# Altium schematic sheet main.SchDoc(main)
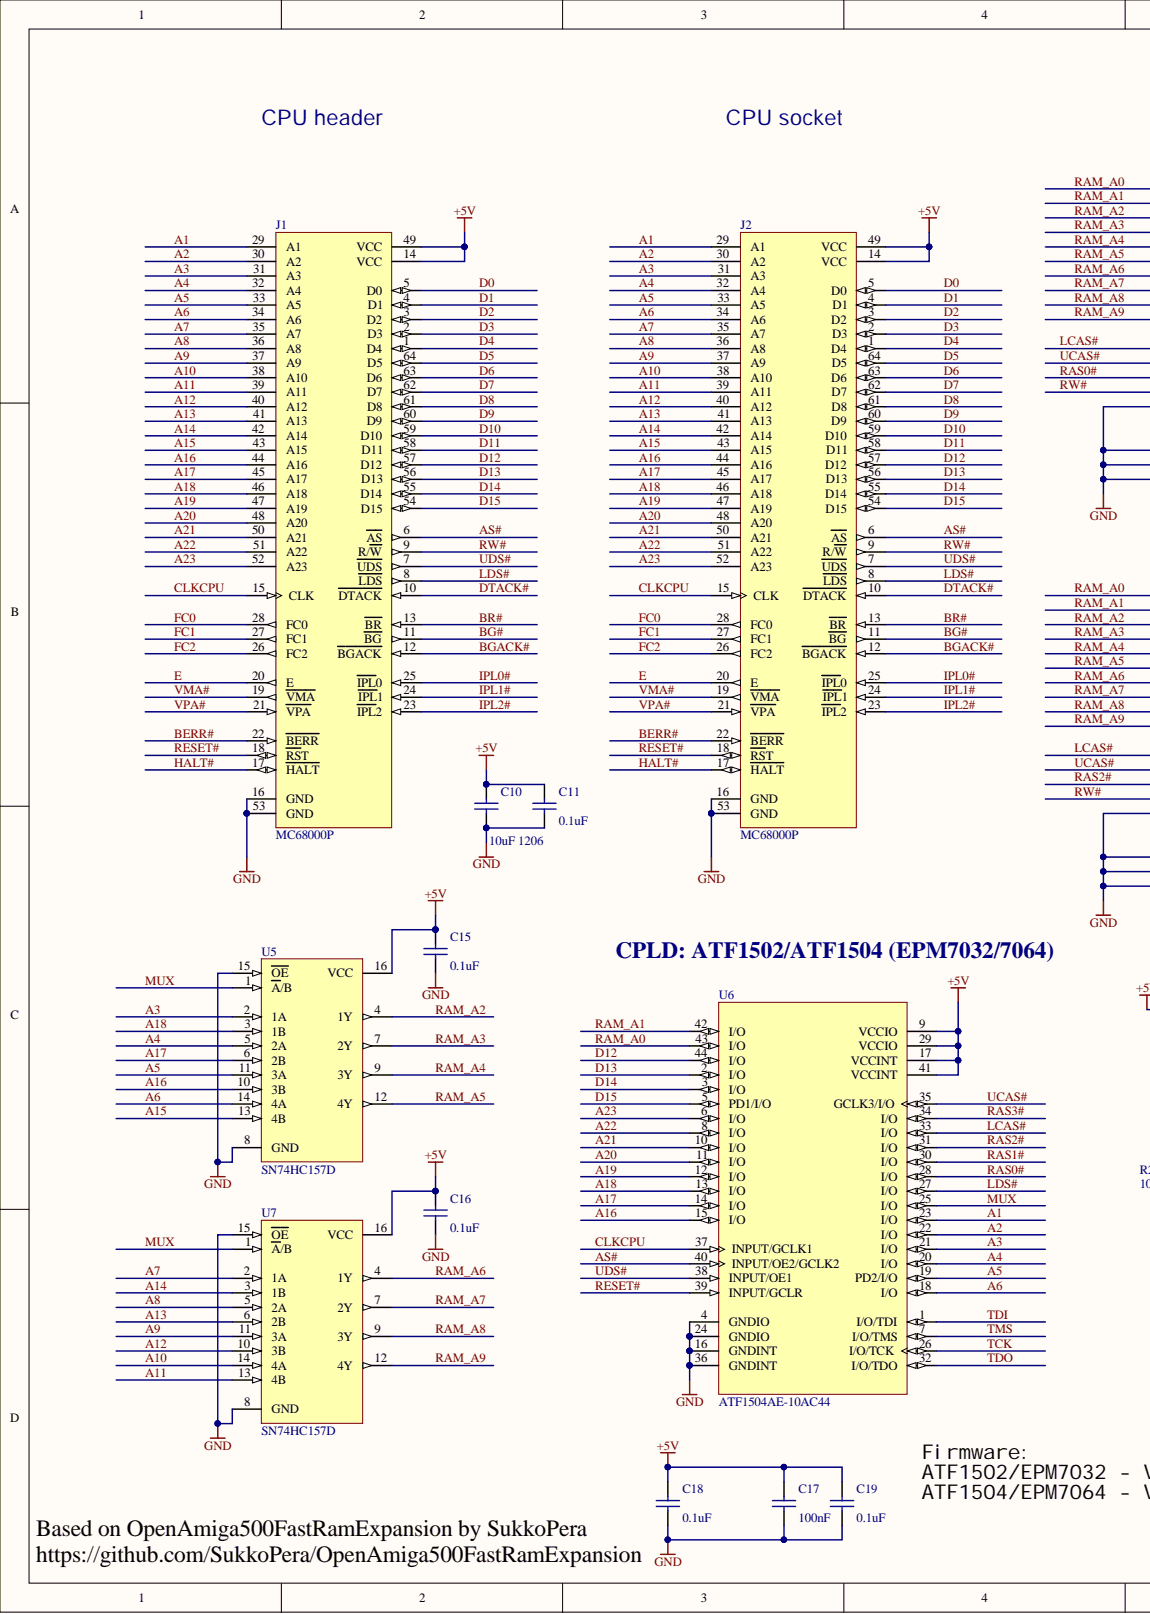
[diagram p0: main.SchDoc, left]
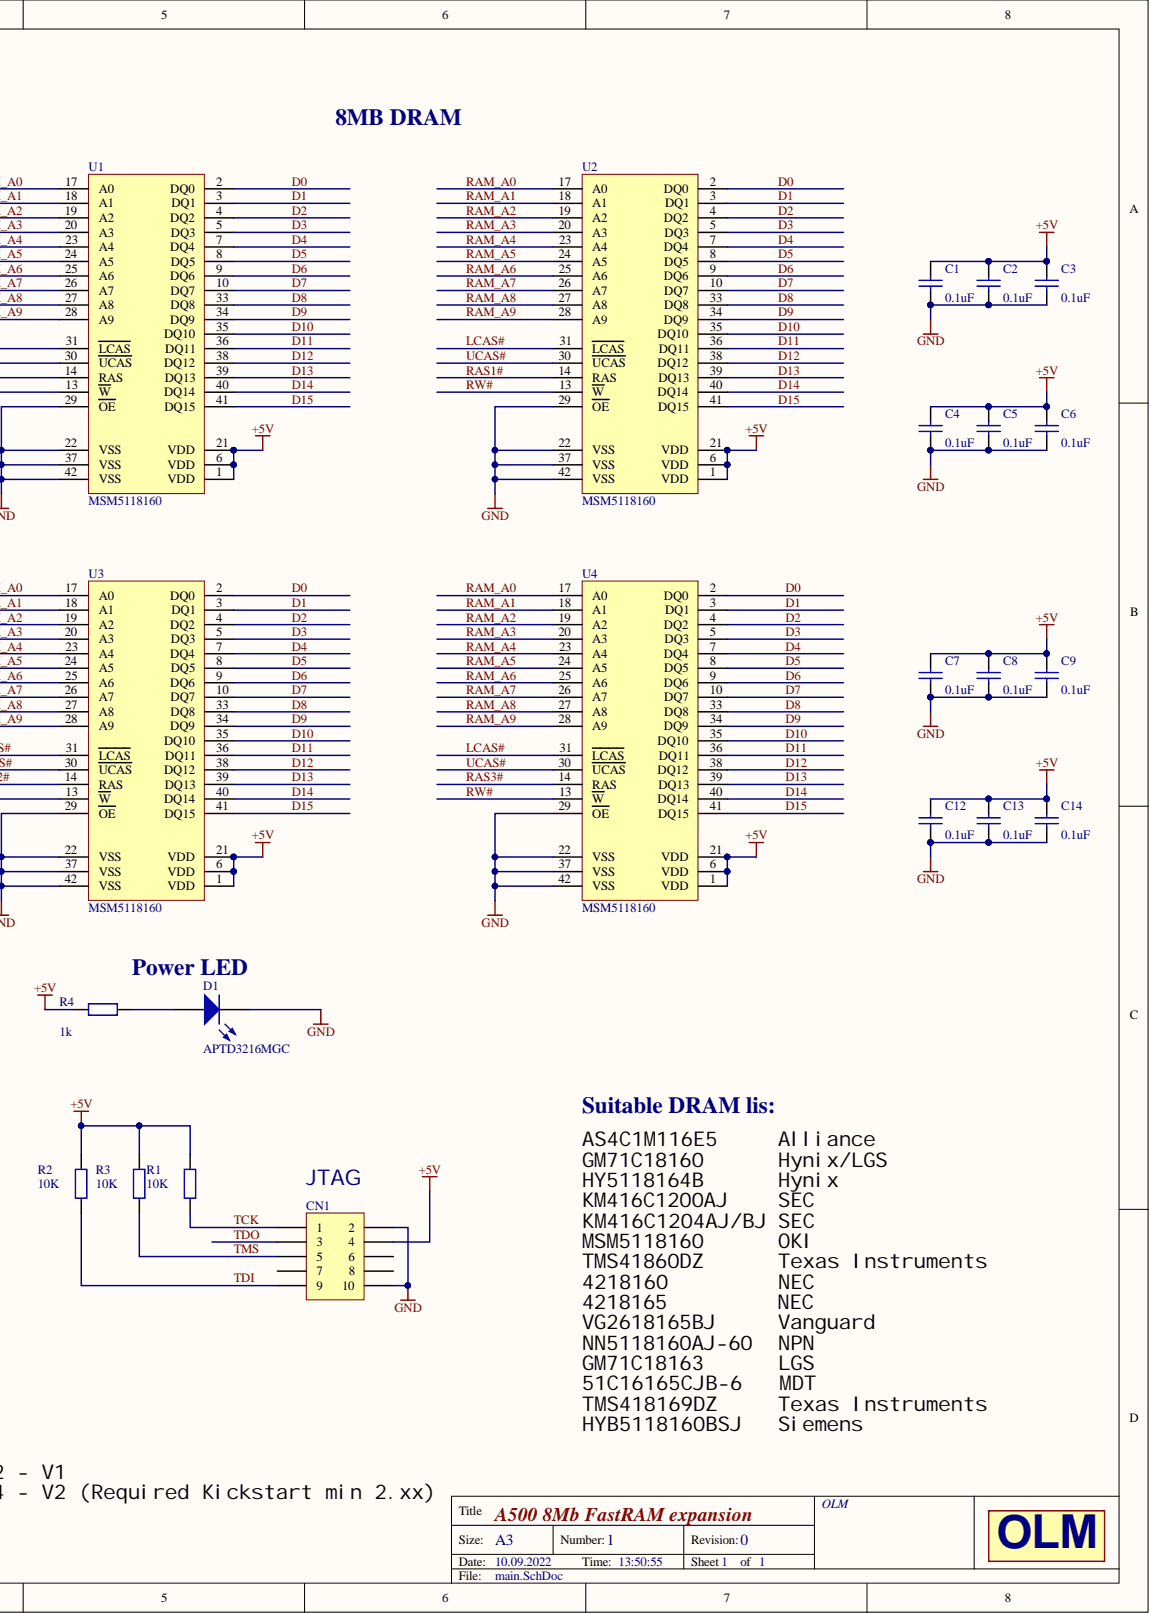
[diagram p1: main.SchDoc, right]

## Parts
C1  value 0.1uF  [SM/C_0805]  (hidden comment: Capacitor)
C2  value 0.1uF  [SM/C_0805]  (hidden comment: Capacitor)
C3  value 0.1uF  [SM/C_0805]  (hidden comment: Capacitor)
C4  value 0.1uF  [SM/C_0805]  (hidden comment: Capacitor)
C5  value 0.1uF  [SM/C_0805]  (hidden comment: Capacitor)
C6  value 0.1uF  [SM/C_0805]  (hidden comment: Capacitor)
C7  value 0.1uF  [SM/C_0805]  (hidden comment: Capacitor)
C8  value 0.1uF  [SM/C_0805]  (hidden comment: Capacitor)
C9  value 0.1uF  [SM/C_0805]  (hidden comment: Capacitor)
C10  value 10uF 1206  [SM/C_1206]  (hidden comment: Capacitor)
C11  value 0.1uF  [SM/C_0805]  (hidden comment: Capacitor)
C12  value 0.1uF  [SM/C_0805]  (hidden comment: Capacitor)
C13  value 0.1uF  [SM/C_0805]  (hidden comment: Capacitor)
C14  value 0.1uF  [SM/C_0805]  (hidden comment: Capacitor)
C15  value 0.1uF  [SM/C_0805]  (hidden comment: Capacitor)
C16  value 0.1uF  [SM/C_0805]  (hidden comment: Capacitor)
C17  value 100nF  [SM/C_0805]  (hidden comment: Cap)
C18  value 0.1uF  [SM/C_0805]  (hidden comment: Capacitor)
C19  value 0.1uF  [SM/C_0805]  (hidden comment: Capacitor)
CN1  Header 5X2H  [PLD-10]  (value not drawn)
D1  value APTD3216MGC  [SM/LED_1206_Green]  (hidden comment: Светодиод)
J1  MC68000P  [DIP.100/64/W.900/L3.225-HEADER]
J2  MC68000P  [DIP.100/64/W.900/L3.225-SKT]
R1  value 10K  [SM/R_0805]  (hidden comment: Resistor)
R2  value 10K  [SM/R_0805]  (hidden comment: Resistor)
R3  value 10K  [SM/R_0805]  (hidden comment: Resistor)
R4  value 1k  [SM/R_0805]  (hidden comment: Resistor)
U1  value MSM5118160  [SOJ-42/400mil]  (hidden comment: 1Mx16 DRAM SOJ42)
U2  value MSM5118160  [SOJ-42/400mil]  (hidden comment: 1Mx16 DRAM SOJ42)
U3  value MSM5118160  [SOJ-42/400mil]  (hidden comment: 1Mx16 DRAM SOJ42)
U4  value MSM5118160  [SOJ-42/400mil]  (hidden comment: 1Mx16 DRAM SOJ42)
U5  SN74HC157D  [D016_N]
U6  ATF1504AE-10AC44  [44A_N]
U7  SN74HC157D  [D016_N]

## Nets
+5V: C1.2 C2.2 C3.2 C4.2 C5.2 C6.2 C7.2 C8.2 C9.2 C10.2 C11.2 C12.2 C13.2 C14.2 C15.2 C16.2 C17.2 C18.2 C19.2 CN1.4 J1.14(VCC) J1.49(VCC) J2.14(VCC) J2.49(VCC) R1.2 R2.2 R3.2 R4.2 U1.1(VDD) U1.6(VDD) U1.21(VDD) U2.1(VDD) U2.6(VDD) U2.21(VDD) U3.1(VDD) U3.6(VDD) U3.21(VDD) U4.1(VDD) U4.6(VDD) U4.21(VDD) U5.16(VCC) U6.9(VCCIO) U6.17(VCCINT) U6.29(VCCIO) U6.41(VCCINT) U7.16(VCC)
GND: C1.1 C2.1 C3.1 C4.1 C5.1 C6.1 C7.1 C8.1 C9.1 C10.1 C11.1 C12.1 C13.1 C14.1 C15.1 C16.1 C17.1 C18.1 C19.1 CN1.2 CN1.10 D1.2 J1.16(GND) J1.53(GND) J2.16(GND) J2.53(GND) U1.22(VSS) U1.29(OE) U1.37(VSS) U1.42(VSS) U2.22(VSS) U2.29(OE) U2.37(VSS) U2.42(VSS) U3.22(VSS) U3.29(OE) U3.37(VSS) U3.42(VSS) U4.22(VSS) U4.29(OE) U4.37(VSS) U4.42(VSS) U5.8(GND) U5.15(OE) U6.4(GNDIO) U6.16(GNDINT) U6.24(GNDIO) U6.36(GNDINT) U7.8(GND) U7.15(OE)
A1: J1.29(A1) J2.29(A1) U6.23(I/O)
A2: J1.30(A2) J2.30(A2) U6.22(I/O)
A3: J1.31(A3) J2.31(A3) U5.2(1A) U6.21(I/O)
A4: J1.32(A4) J2.32(A4) U5.5(2A) U6.20(I/O)
A5: J1.33(A5) J2.33(A5) U5.11(3A) U6.19(PD2/I/O)
A6: J1.34(A6) J2.34(A6) U5.14(4A) U6.18(I/O)
A7: J1.35(A7) J2.35(A7) U7.2(1A)
A8: J1.36(A8) J2.36(A8) U7.5(2A)
A9: J1.37(A9) J2.37(A9) U7.11(3A)
A10: J1.38(A10) J2.38(A10) U7.14(4A)
A11: J1.39(A11) J2.39(A11) U7.13(4B)
A12: J1.40(A12) J2.40(A12) U7.10(3B)
A13: J1.41(A13) J2.41(A13) U7.6(2B)
A14: J1.42(A14) J2.42(A14) U7.3(1B)
A15: J1.43(A15) J2.43(A15) U5.13(4B)
A16: J1.44(A16) J2.44(A16) U5.10(3B) U6.15(I/O)
A17: J1.45(A17) J2.45(A17) U5.6(2B) U6.14(I/O)
A18: J1.46(A18) J2.46(A18) U5.3(1B) U6.13(I/O)
A19: J1.47(A19) J2.47(A19) U6.12(I/O)
A20: J1.48(A20) J2.48(A20) U6.11(I/O)
A21: J1.50(A21) J2.50(A21) U6.10(I/O)
A22: J1.51(A22) J2.51(A22) U6.8(I/O)
A23: J1.52(A23) J2.52(A23) U6.6(I/O)
AS#: J1.6(AS) J2.6(AS) U6.40(INPUT/OE2/GCLK2)
BERR#: J1.22(BERR) J2.22(BERR)
BG#: J1.11(BG) J2.11(BG)
BGACK#: J1.12(BGACK) J2.12(BGACK)
BR#: J1.13(BR) J2.13(BR)
CLKCPU: J1.15(CLK) J2.15(CLK) U6.37(INPUT/GCLK1)
D0: J1.5(D0) J2.5(D0) U1.2(DQ0) U2.2(DQ0) U3.2(DQ0) U4.2(DQ0)
D1: J1.4(D1) J2.4(D1) U1.3(DQ1) U2.3(DQ1) U3.3(DQ1) U4.3(DQ1)
D2: J1.3(D2) J2.3(D2) U1.4(DQ2) U2.4(DQ2) U3.4(DQ2) U4.4(DQ2)
D3: J1.2(D3) J2.2(D3) U1.5(DQ3) U2.5(DQ3) U3.5(DQ3) U4.5(DQ3)
D4: J1.1(D4) J2.1(D4) U1.7(DQ4) U2.7(DQ4) U3.7(DQ4) U4.7(DQ4)
D5: J1.64(D5) J2.64(D5) U1.8(DQ5) U2.8(DQ5) U3.8(DQ5) U4.8(DQ5)
D6: J1.63(D6) J2.63(D6) U1.9(DQ6) U2.9(DQ6) U3.9(DQ6) U4.9(DQ6)
D7: J1.62(D7) J2.62(D7) U1.10(DQ7) U2.10(DQ7) U3.10(DQ7) U4.10(DQ7)
D8: J1.61(D8) J2.61(D8) U1.33(DQ8) U2.33(DQ8) U3.33(DQ8) U4.33(DQ8)
D9: J1.60(D9) J2.60(D9) U1.34(DQ9) U2.34(DQ9) U3.34(DQ9) U4.34(DQ9)
D10: J1.59(D10) J2.59(D10) U1.35(DQ10) U2.35(DQ10) U3.35(DQ10) U4.35(DQ10)
D11: J1.58(D11) J2.58(D11) U1.36(DQ11) U2.36(DQ11) U3.36(DQ11) U4.36(DQ11)
D12: J1.57(D12) J2.57(D12) U1.38(DQ12) U2.38(DQ12) U3.38(DQ12) U4.38(DQ12) U6.44(I/O)
D13: J1.56(D13) J2.56(D13) U1.39(DQ13) U2.39(DQ13) U3.39(DQ13) U4.39(DQ13) U6.2(I/O)
D14: J1.55(D14) J2.55(D14) U1.40(DQ14) U2.40(DQ14) U3.40(DQ14) U4.40(DQ14) U6.3(I/O)
D15: J1.54(D15) J2.54(D15) U1.41(DQ15) U2.41(DQ15) U3.41(DQ15) U4.41(DQ15) U6.5(PD1/I/O)
DTACK#: J1.10(DTACK) J2.10(DTACK)
E: J1.20(E) J2.20(E)
FC0: J1.28(FC0) J2.28(FC0)
FC1: J1.27(FC1) J2.27(FC1)
FC2: J1.26(FC2) J2.26(FC2)
HALT#: J1.17(HALT) J2.17(HALT)
IPL0#: J1.25(IPL0) J2.25(IPL0)
IPL1#: J1.24(IPL1) J2.24(IPL1)
IPL2#: J1.23(IPL2) J2.23(IPL2)
LCAS#: U1.31(LCAS) U2.31(LCAS) U3.31(LCAS) U4.31(LCAS) U6.33(I/O)
LDS#: J1.8(LDS) J2.8(LDS) U6.27(I/O)
MUX: U5.1(A/B) U6.25(I/O) U7.1(A/B)
RAM_A0: U1.17(A0) U2.17(A0) U3.17(A0) U4.17(A0) U6.43(I/O)
RAM_A1: U1.18(A1) U2.18(A1) U3.18(A1) U4.18(A1) U6.42(I/O)
RAM_A2: U1.19(A2) U2.19(A2) U3.19(A2) U4.19(A2) U5.4(1Y)
RAM_A3: U1.20(A3) U2.20(A3) U3.20(A3) U4.20(A3) U5.7(2Y)
RAM_A4: U1.23(A4) U2.23(A4) U3.23(A4) U4.23(A4) U5.9(3Y)
RAM_A5: U1.24(A5) U2.24(A5) U3.24(A5) U4.24(A5) U5.12(4Y)
RAM_A6: U1.25(A6) U2.25(A6) U3.25(A6) U4.25(A6) U7.4(1Y)
RAM_A7: U1.26(A7) U2.26(A7) U3.26(A7) U4.26(A7) U7.7(2Y)
RAM_A8: U1.27(A8) U2.27(A8) U3.27(A8) U4.27(A8) U7.9(3Y)
RAM_A9: U1.28(A9) U2.28(A9) U3.28(A9) U4.28(A9) U7.12(4Y)
RAS0#: U1.14(RAS) U6.28(I/O)
RAS1#: U2.14(RAS) U6.30(I/O)
RAS2#: U3.14(RAS) U6.31(I/O)
RAS3#: U4.14(RAS) U6.34(I/O)
RESET#: J1.18(RST) J2.18(RST) U6.39(INPUT/GCLR)
RW#: J1.9(R/W) J2.9(R/W) U1.13(W) U2.13(W) U3.13(W) U4.13(W)
TCK: CN1.1 R1.1 U6.26(I/O/TCK)
TDI: CN1.9 R2.1 U6.1(I/O/TDI)
TDO: CN1.3 U6.32(I/O/TDO)
TMS: CN1.5 R3.1 U6.7(I/O/TMS)
UCAS#: U1.30(UCAS) U2.30(UCAS) U3.30(UCAS) U4.30(UCAS) U6.35(GCLK3/I/O)
UDS#: J1.7(UDS) J2.7(UDS) U6.38(INPUT/OE1)
VMA#: J1.19(VMA) J2.19(VMA)
VPA#: J1.21(VPA) J2.21(VPA)
NetD1_1: D1.1 R4.1

Not connected: CN1 6 7 8

## Library data of the parts
C1-C9 C11-C16 C18 C19 = Cap ceramic: Ceramic capacitor SMD; Manufacturer: Samsung; Part: CL10B104KB8NNNL; Component Kind: Standard; Library Name: New.SCHLIB
C10 = Cap ceramic: Ceramic capacitor SMD; Manufacturer: Kemet; Part: C1206C106K4RACTU; Value: 10uF 1206; Component Kind: Standard; Library Name: New.SCHLIB
C17 = Cap ceramic: CAP-100Nf; Component Kind: Standard; Library Name: New.SCHLIB
CN1 = Header 5X2H: Header, 5-Pin, Dual row, Right Angle; Component Kind: Standard; LatestRevisionDate: 17-Jul-2002; LatestRevisionNote: Re-released for DXP Platform.; Library Name: Miscellaneous Connectors.IntLib; Signal Integrity: Connector; Publisher: Altium Limited
D1 = LED0: Typical INFRARED GaAs LED; Примечание: Kingbright; Component Kind: Standard; LatestRevisionDate: 08-Jul-2005; LatestRevisionNote: Mech Layer 1 removed.; Library Name: Miscellaneous Devices.IntLib; PackageDescription: LED; 2 Leads; PackageReference: LED-0; Published: 8-Jun-2000; Simulation: LED0; Publisher: Altium Limited
J1 J2 = MC68000P: 16-Bit/32-Bit Microprocessor; Value: Socket 1-pin x 64; Component Kind: Standard; DatasheetVersion: 1990; LatestRevisionDate: 06-Jun-2005; LatestRevisionNote: Stylized 3D Model Added.; Library Name: Motorola Microprocessor 16-Bit.IntLib; PackageDescription: DIP; 64 Leads; Row Spacing 22.86 mm; Pitch 2.54 mm; PackageReference: 754-01; PCB3D: 746-01; Published: 17-Jul-2002; Publisher: Altium Limited
R1-R3 = Res2: Part: 0R 5% 0603; Component Kind: Standard; Description: Resistor; LatestRevisionDate: 17-Jul-2002; LatestRevisionNote: Re-released for DXP Platform.; Library Name: Miscellaneous Devices.IntLib; PackageReference: AXIAL-0.4; Published: 8-Jun-2000; Signal Integrity: Res; Simulation: RESISTOR; Publisher: Altium Limited
R4 = Res2: Component Kind: Standard; Description: Resistor; LatestRevisionDate: 17-Jul-2002; LatestRevisionNote: Re-released for DXP Platform.; Library Name: Miscellaneous Devices.IntLib; PackageReference: AXIAL-0.4; Published: 8-Jun-2000; Signal Integrity: Res; Simulation: RESISTOR; Publisher: Altium Limited
U1-U4 = 1Mx16 DRAM: Component Kind: Standard; Description: 1Mx16 DRAM; Library Name: Memory.SchLib
U5 U7 = SN74HC157D: Quadruple 2-Line to 1-Line Data Selector/Multiplexer; Code_IPC: SOIC127P600-16; Component Kind: Standard; ComponentLink1Description: Manufacturer Link; ComponentLink1URL: http://www.ti.com/; ComponentLink2Description: Datasheet; ComponentLink2URL: http://www-s.ti.com/sc/ds/sn74hc157.pdf; DatasheetVersion: May-1997; LatestRevisionDate: 13-Apr-2006; LatestRevisionNote: IPC-7351 Footprint Added.; Library Name: TI Logic Multiplexer.IntLib; PackageDescription: 16-Pin Small Outline Integrated Circuit 1.27 mm Pitch; PackageReference: D016; PackageVersion: Jan-1998; PCB3D: D016; Published: 8-Jun-2000; Signal Integrity: SN74HC157D; Publisher: Altium Limited
U6 = ATF1504AE-10AC44: ATF1504AE 5.0V CPLD, 64 Macrocells, 44-Lead TQFP, Speed Grade 10, Commercial Grade; Component Kind: Standard; ComponentLink1Description: Manufacturer Link; ComponentLink1URL: http://www.atmel.com/products/pld/default.asp; ComponentLink2Description: Atmel.com Home; ComponentLink2URL: http://www.atmel.com; DatasheetVersion: Rev. 2398H-PLD-8/03; LatestRevisionDate: 13-Apr-2006; LatestRevisionNote: IPC-7351 Footprint Added.; PackageDescription: 44-Pin Plastic Thin Profile Quad Flat Pack, 10 x 10 x 1.0 mm Body, 0.8 mm Pitch (TQFP); PackageReference: 44A; PackageVersion: rev.B, Oct-2001; Published: 19-Mar-2004; Library Name: Atmel Industry Compatible CPLD.IntLib; Publisher: Altium Limited
